# Altium schematic sheet Connector.SchDoc
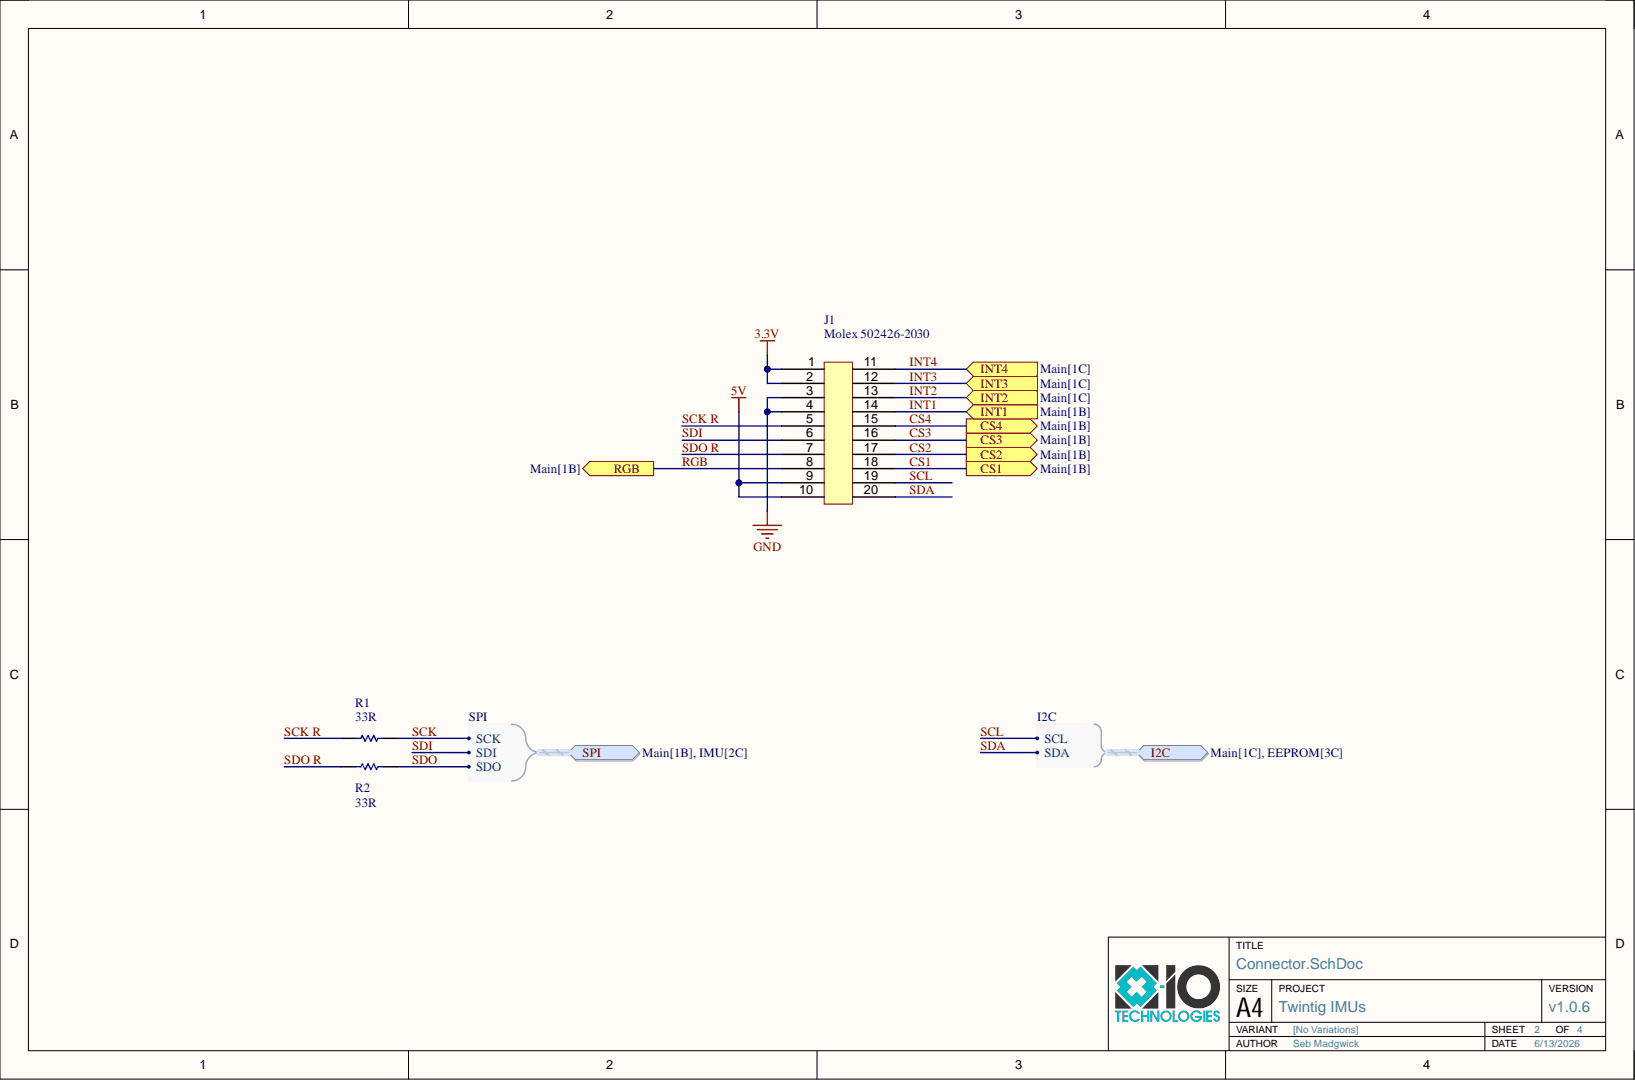
[diagram p0: Connector.SchDoc]

## Parts
J1  Molex 502426-2030  [Molex 502426-2030]
R1  33R  [Resistor 0201]
R2  33R  [Resistor 0201]

## Ports
CS1, CS2, CS3, CS4, I2C, I2C.SCL, I2C.SDA, INT1, INT2, INT3, INT4, RGB, SPI, SPI.SCK, SPI.SDI, SPI.SDO

## Nets
3.3V: J1.1 J1.2
5V: J1.9 J1.10
GND: J1.3 J1.4
CS1: J1.18 ; port CS1
CS2: J1.17 ; port CS2
CS3: J1.16 ; port CS3
CS4: J1.15 ; port CS4
INT1: J1.14 ; port INT1
INT2: J1.13 ; port INT2
INT3: J1.12 ; port INT3
INT4: J1.11 ; port INT4
RGB: J1.8 ; port RGB
SCK: R1.2 ; port SPI
SCK R: J1.5 R1.1
SCL: J1.19 ; port I2C
SDA: J1.20 ; port I2C
SDI: J1.6 ; port SPI
SDO: R2.2 ; port SPI
SDO R: J1.7 R2.1

## Library data of the parts
R1 R2 = Resistor: Component Kind: Standard; Library Name: x-io.SchLib
J1 = Molex 502426-2030: Component Kind: Standard; Library Name: x-io.SchLib
